# Altium schematic sheet Simplex-Rev2v0.SchDoc(Simplex-Rev2v0)
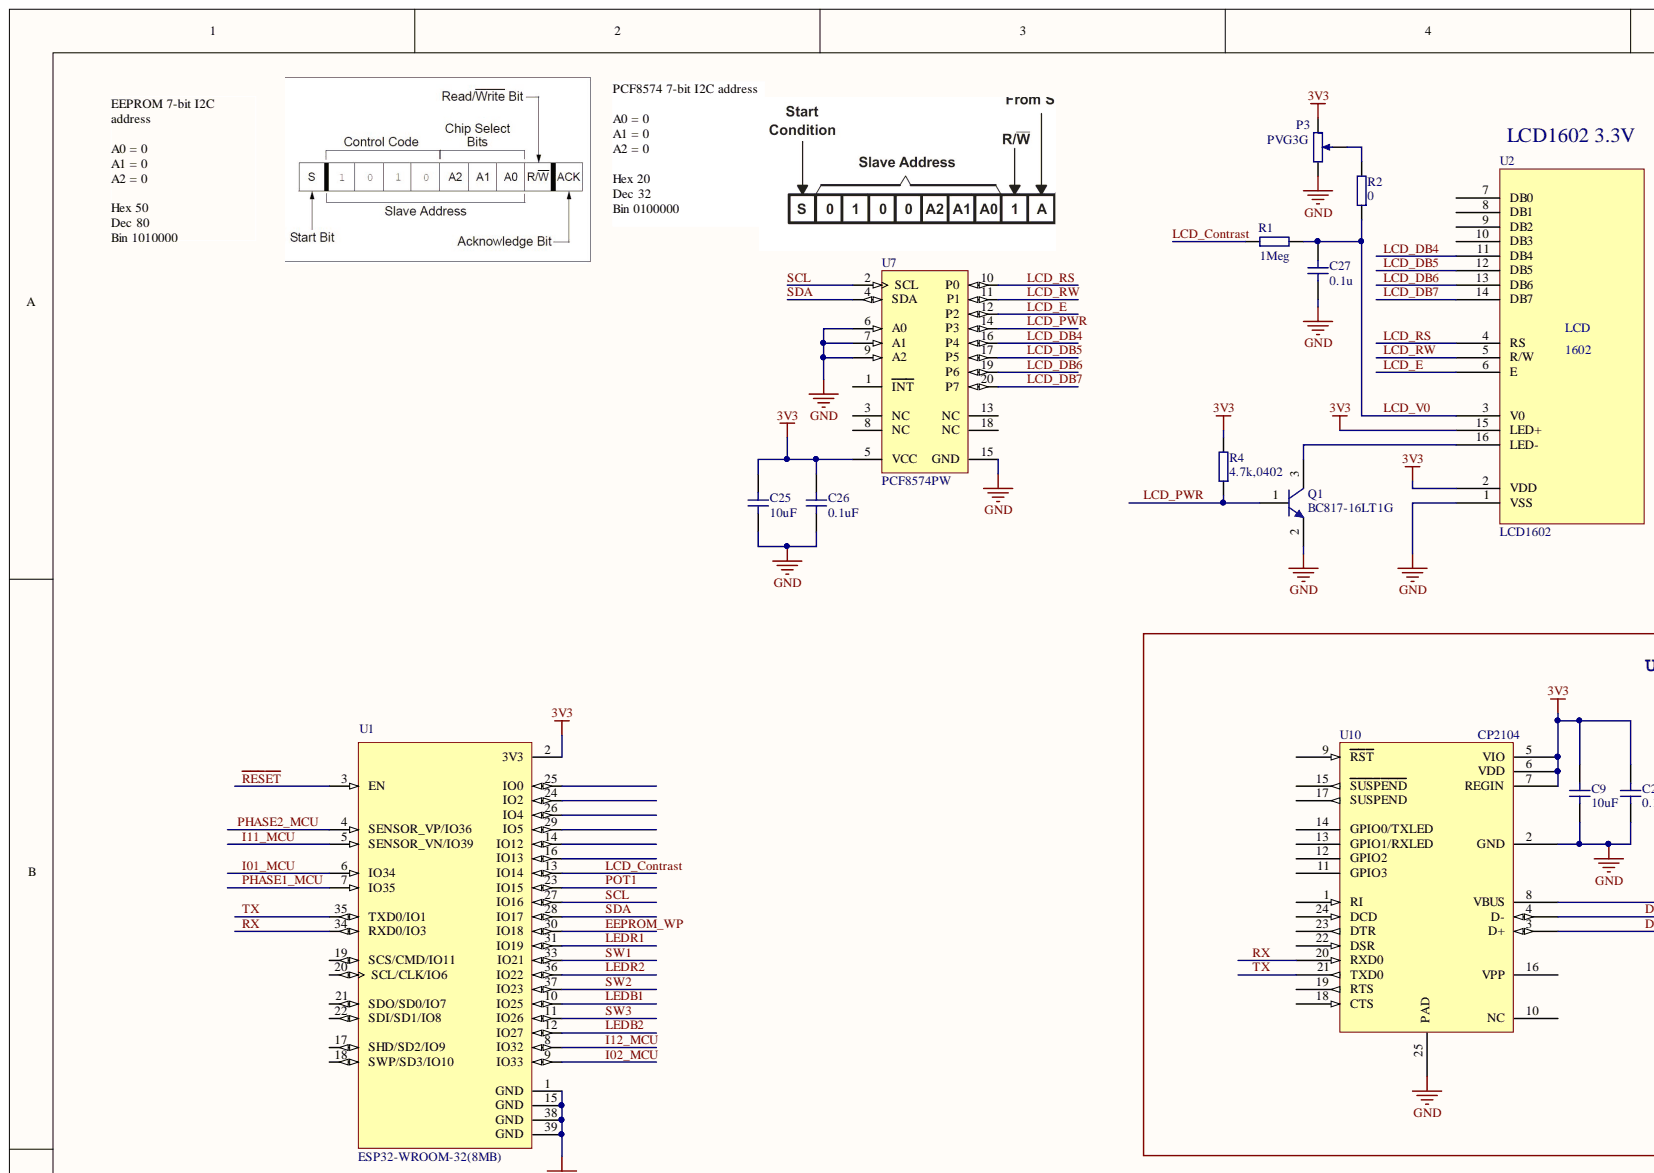
[diagram p0: Simplex-Rev2v0.SchDoc, top left]
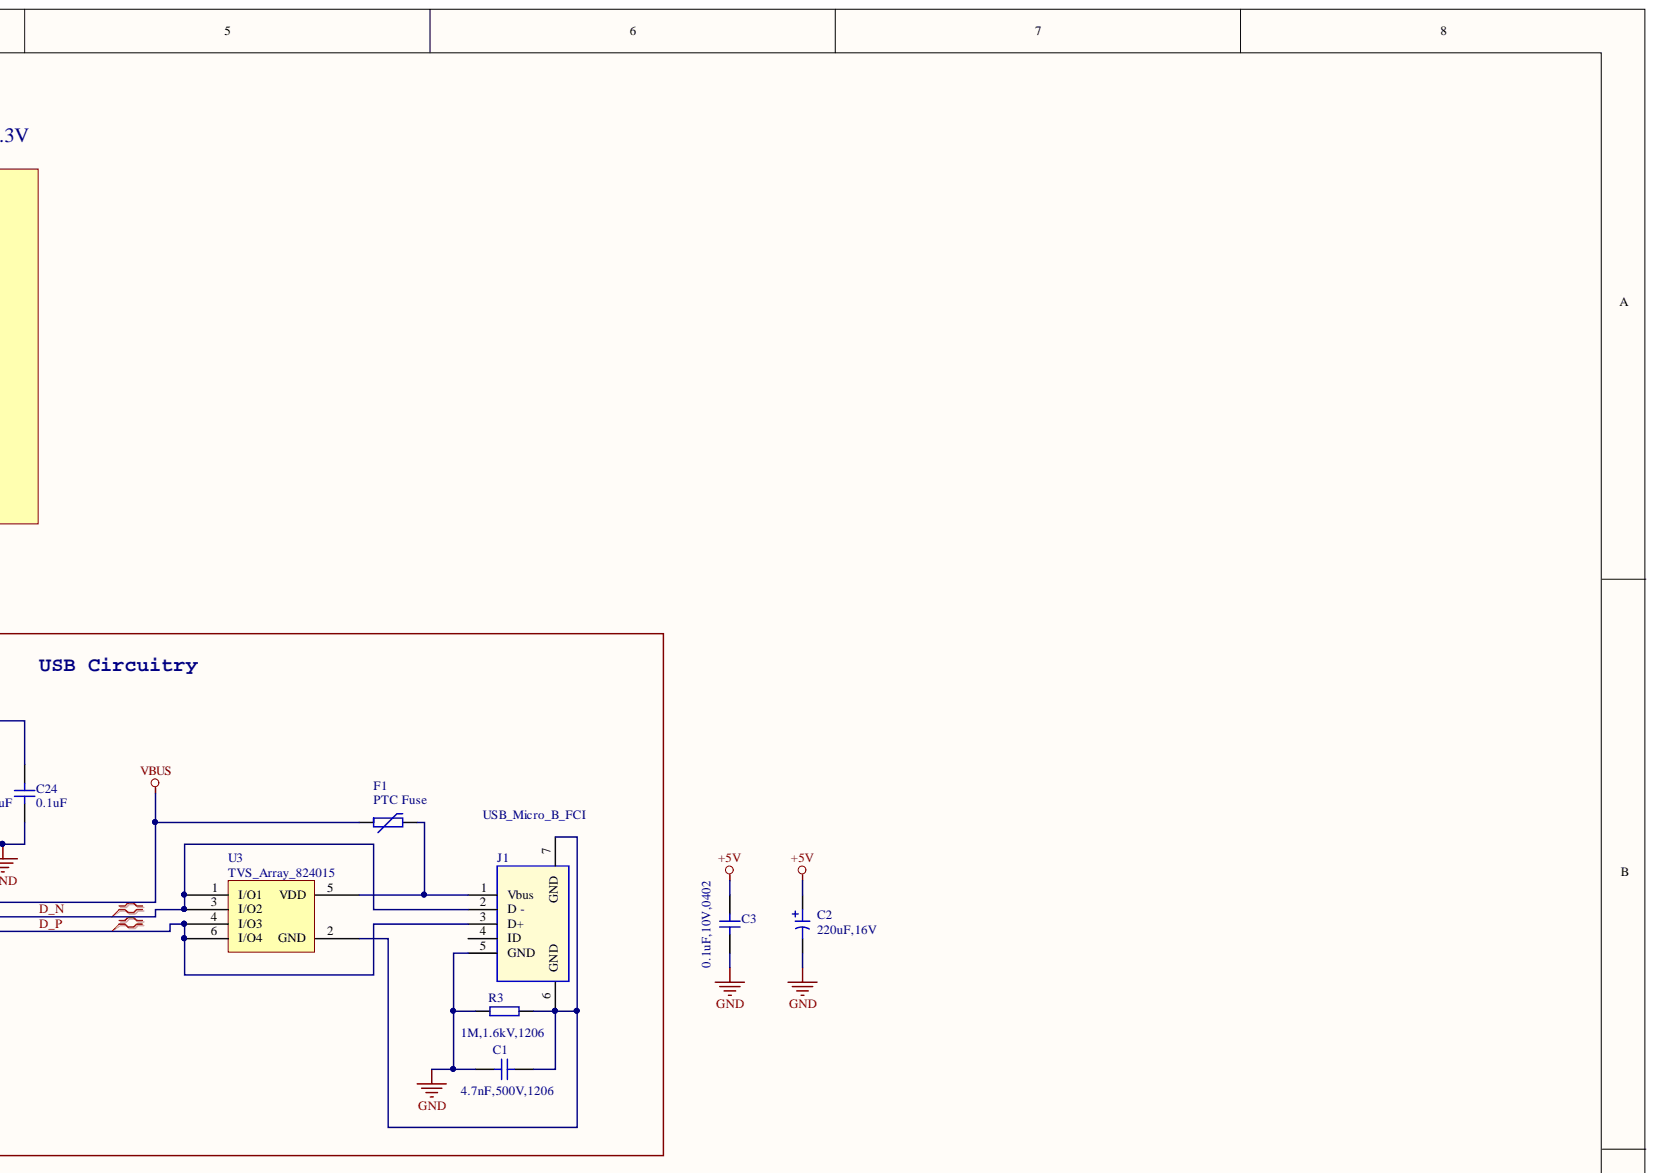
[diagram p1: Simplex-Rev2v0.SchDoc, top right]
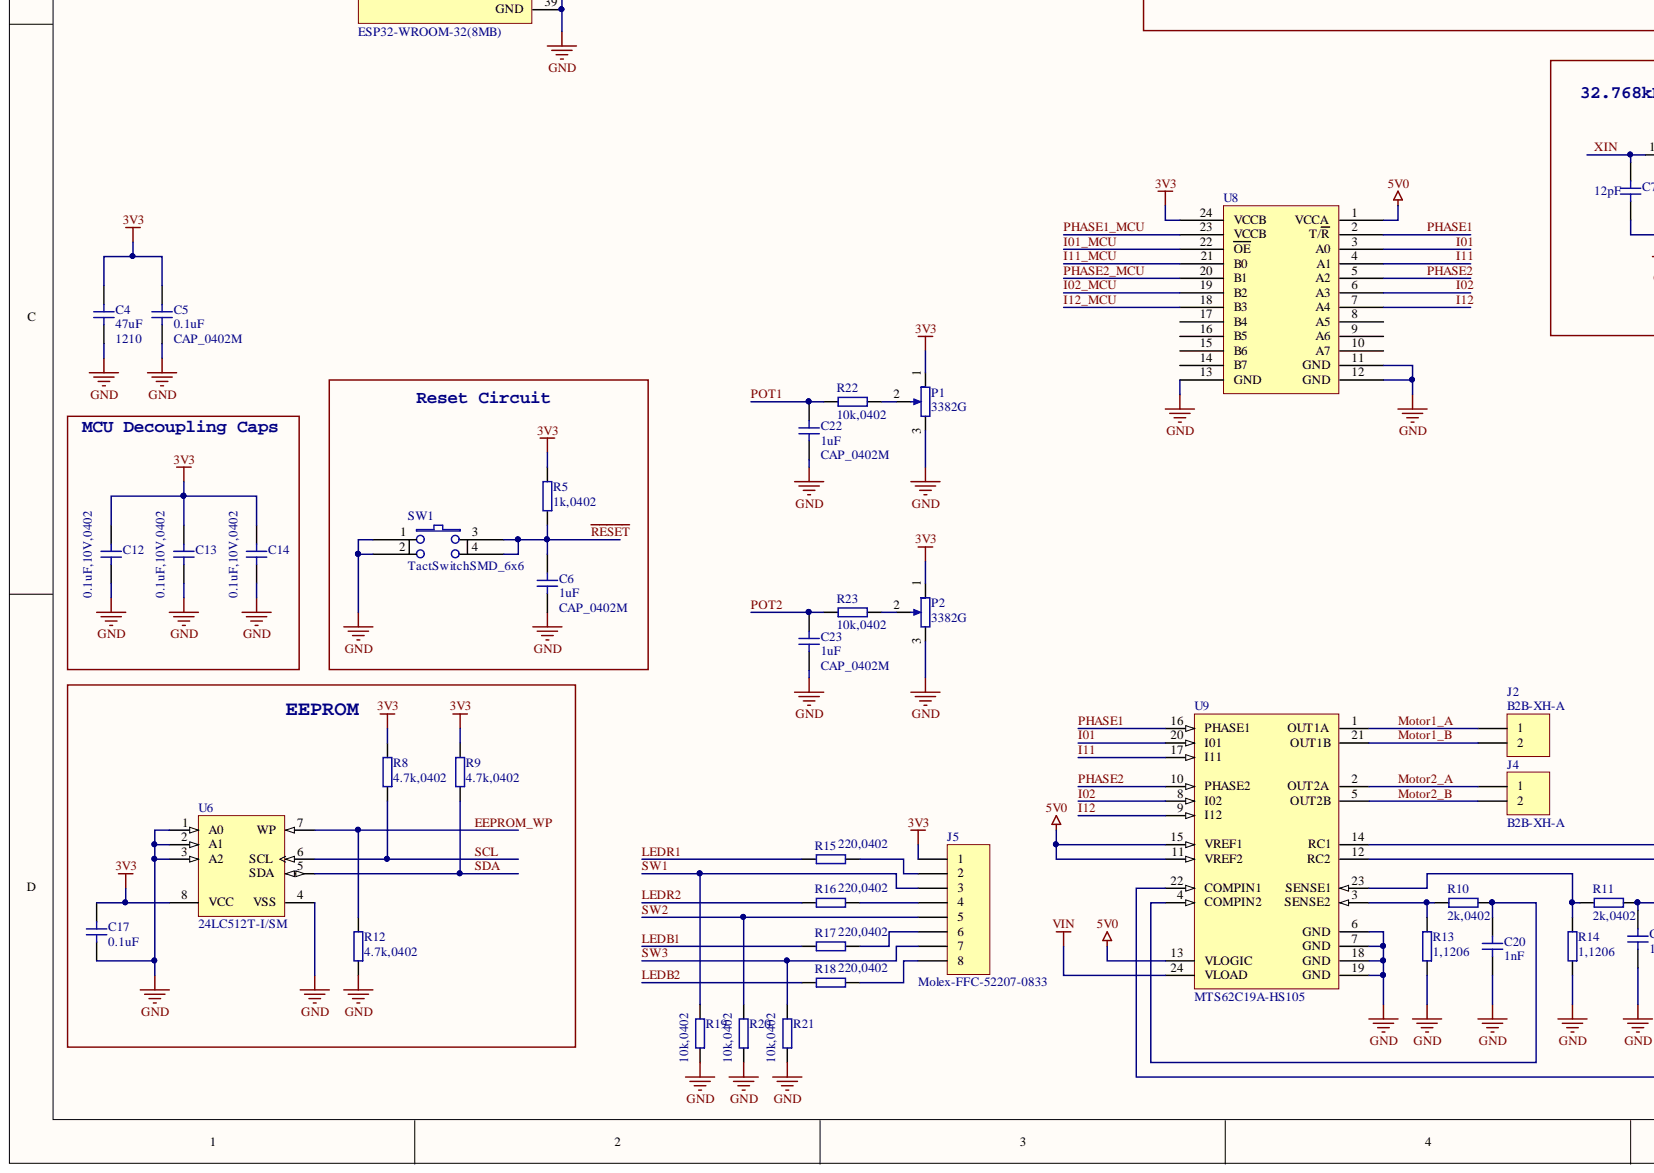
[diagram p2: Simplex-Rev2v0.SchDoc, bottom left]
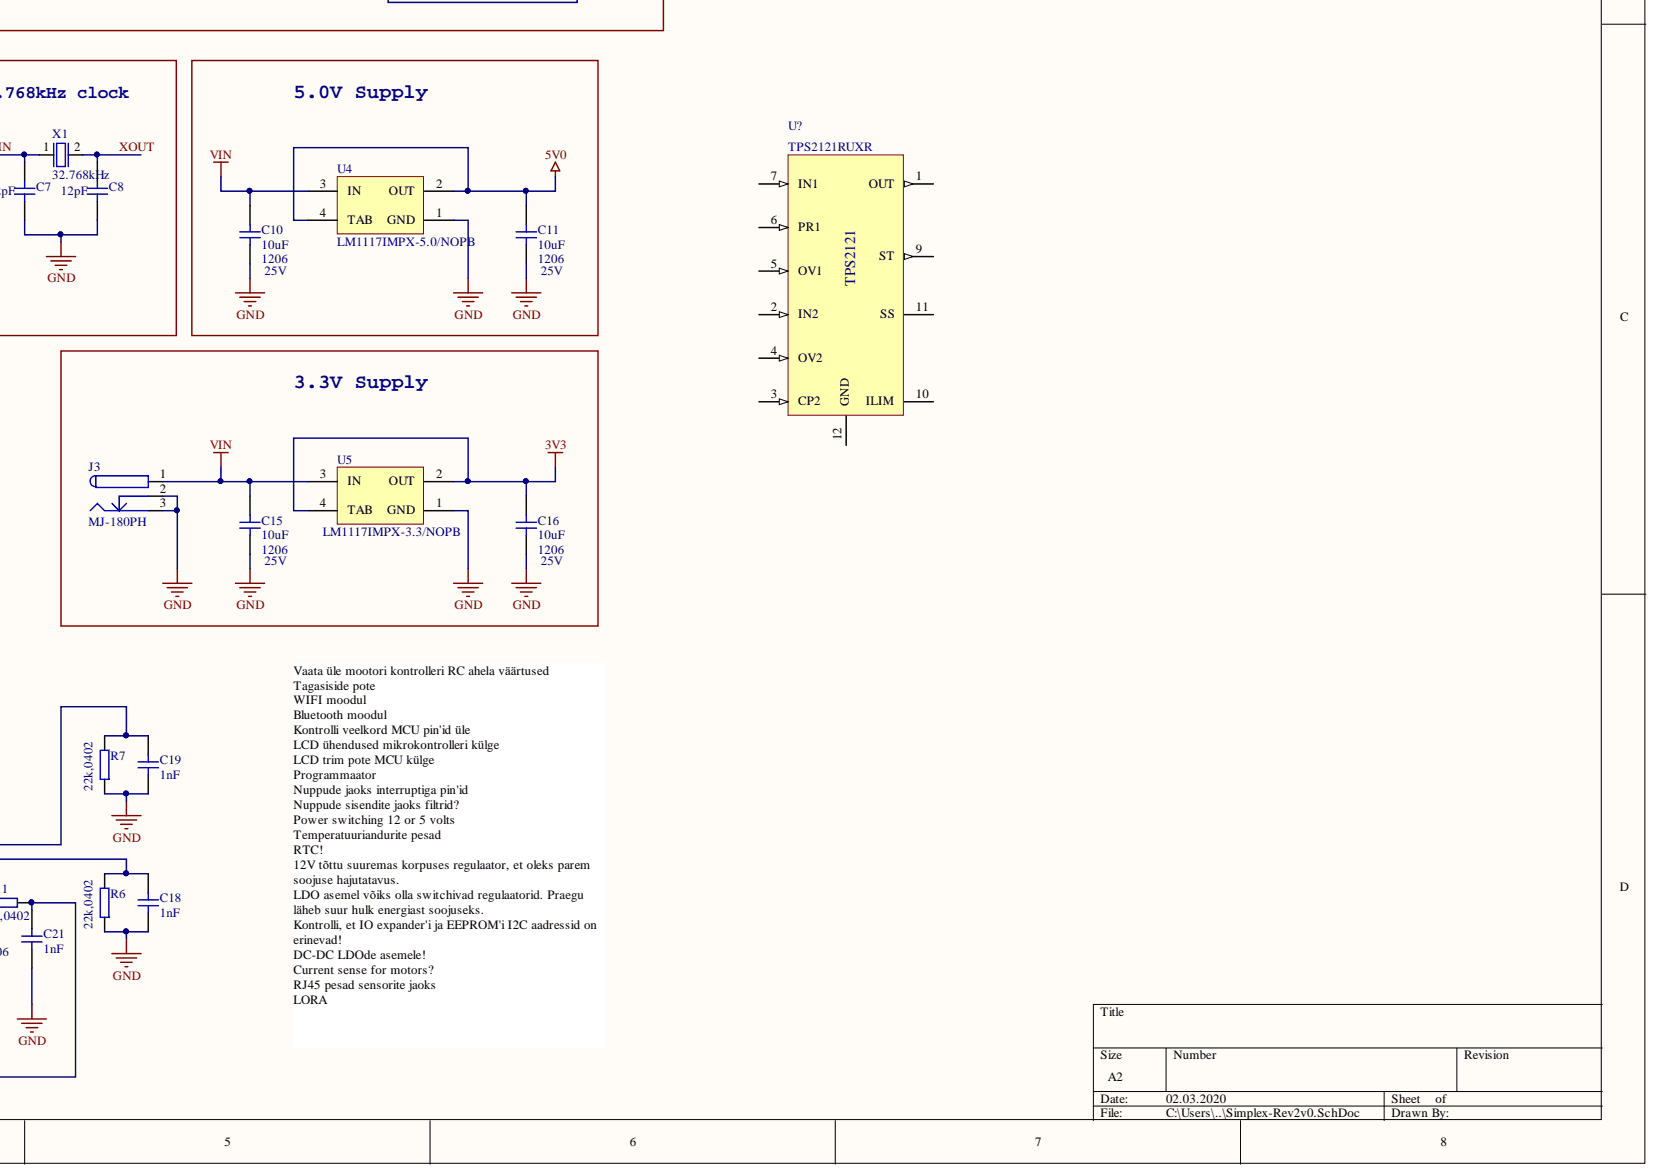
[diagram p3: Simplex-Rev2v0.SchDoc, bottom right]

## Parts
C1  4.7nF,500V,1206  [1206]
C2  220uF,16V  [Electrolytic_6.3x5.4_22u]
C3  0.1uF,10V,0402  [0402]
C4  value 47uF  [1210]  (hidden comment: 47uF,10V,1210)
C5  value 0.1uF  [CAP_0402M]  (hidden comment: 0.1uF,10V,CAP_0402M)
C6  value 1uF  [CAP_0402M]  (hidden comment: 1uF,10V,CAP_0402M)
C7  value 12pF  [0402]  (hidden comment: 12pF,10V,0402)
C8  value 12pF  [0402]  (hidden comment: 12pF,10V,0402)
C9  value 10uF  [CAP_0603_GEN]  (hidden comment: 10uF,10V,CAP_0603_GEN)
C10  value 10uF  Voltage: 25V  [1206]  (hidden comment: 10uF,25V,1206)
C11  value 10uF  Voltage: 25V  [1206]  (hidden comment: 10uF,25V,1206)
C12  0.1uF,10V,0402  [CAP_0402]
C13  0.1uF,10V,0402  [CAP_0402]
C14  0.1uF,10V,0402  [CAP_0402]
C15  value 10uF  Voltage: 25V  [1206]  (hidden comment: 10uF,25V,1206)
C16  value 10uF  Voltage: 25V  [1206]  (hidden comment: 10uF,25V,1206)
C17  value 0.1uF  [CAP_0402M]  (hidden comment: 0.1uF,10V,CAP_0402M)
C18  value 1nF  [CAP_0402M]  (hidden comment: 1nF,10V,CAP_0402M)
C19  value 1nF  [CAP_0402M]  (hidden comment: 1nF,10V,CAP_0402M)
C20  value 1nF  [CAP_0402M]  (hidden comment: 1nF,10V,CAP_0402M)
C21  value 1nF  [CAP_0402M]  (hidden comment: 1nF,10V,CAP_0402M)
C22  value 1uF  [CAP_0402M]  (hidden comment: 1uF,10V,CAP_0402M)
C23  value 1uF  [CAP_0402M]  (hidden comment: 1uF,10V,CAP_0402M)
C24  value 0.1uF  [CAP_0402M]  (hidden comment: 0.1uF,10V,CAP_0402M)
C25  value 10uF  [CAP_0603_GEN]  (hidden comment: 10uF,10V,CAP_0603_GEN)
C26  value 0.1uF  [CAP_0402M]  (hidden comment: 0.1uF,10V,CAP_0402M)
C27  value 0.1u  [CAP_0402M]  (hidden comment: 0.1u,10V,CAP_0402M)
F1  PTC Fuse  [0603_PTC_Fuse]
J1  USB_Micro_B_FCI  [USB_Micro_B_10104110]
J2  B2B-XH-A  [B2B-XH-A]
J3  MJ-180PH  [MJ-180PH]
J4  B2B-XH-A  [B2B-XH-A]
J5  Molex-FFC-52207-0833  [Molex-FFC-52207-0833]
P1  3382G  [3382G]
P2  3382G  [3382G]
P3  PVG3G  [PVG3G]
Q1  BC817-16LT1G  [ONSC-SOT-23-3-318-08_V]
R1  value 1Meg  [RES_0402M]  (hidden comment: 1Meg,RES_0402M)
R2  value 0  [RES_0402M]  (hidden comment: 0,RES_0402M)
R3  1M,1.6kV,1206  [1206]
R4  4.7k,0402  [0402]
R5  1k,0402  [0402]
R6  22k,0402  [0402]
R7  22k,0402  [0402]
R8  4.7k,0402  [0402]
R9  4.7k,0402  [0402]
R10  2k,0402  [0402]
R11  2k,0402  [0402]
R12  4.7k,0402  [0402]
R13  1,1206  [1206]
R14  1,1206  [1206]
R15  220,0402  [0402]
R16  220,0402  [0402]
R17  220,0402  [0402]
R18  220,0402  [0402]
R19  10k,0402  [0402]
R20  10k,0402  [0402]
R21  10k,0402  [0402]
R22  10k,0402  [0402]
R23  10k,0402  [0402]
SW1  TactSwitchSMD_6x6  [TactSwitchSMD_6x6 - 2]
U1  ESP32-WROOM-32(8MB)  [ESP32-WROOM-32(8MB)]
U2  LCD1602  [LCD1602]
U3  TVS_Array_824015  [SOT23-6_N_Thin]
U4  LM1117IMPX-5.0/NOPB  [MP04A_N]
U5  LM1117IMPX-3.3/NOPB  [MP04A_N]
U6  24LC512T-I/SM  [SOIJ-SM8_N]
U7  PCF8574PW  [PW20_N]
U8  74LVX4245MTCX  [TSSOP28_L]  (value not drawn)
U9  MTS62C19A-HS105  [MCHP-SOP-S24_N]
U10  CP2104  [QFN-24]
U?  TPS2121RUXR  [TPS2121RUX]
X1  Frequency: 32.768kHz  [Cryastal_2PIN_SMD]  (hidden comment: Part Number)

## Nets
3V3: C4.2 C5.2 C9.2 C12.1 C13.1 C14.1 C16.2 C17.2 C24.2 C25.2 C26.2 J5.1 P1.1 P2.1 P3.1 R4.2 R5.2 R8.2 R9.2 U1.2(3V3) U2.2(VDD) U2.15(LED+) U5.2(OUT) U5.4(TAB) U6.8(VCC) U7.5(VCC) U8.24(VCCB) U10.5(VIO) U10.6(VDD) U10.7(REGIN)
5V0: C11.2 U4.2(OUT) U4.4(TAB) U8.1(VCCA) U9.11(VREF2) U9.13(VLOGIC) U9.15(VREF1)
+5V: C2.1 C3.2
GND: C1.1 C2.2 C3.1 C4.1 C5.1 C6.1 C7.1 C8.1 C9.1 C10.1 C11.1 C12.2 C13.2 C14.2 C15.1 C16.1 C17.1 C18.1 C19.1 C20.1 C21.1 C22.1 C23.1 C24.1 C25.1 C26.1 C27.1 J1.5(GND) J3.2 J3.3 P1.3 P2.3 P3.3 Q1.2 R3.1 R6.1 R7.1 R12.1 R13.1 R14.1 R19.1 R20.1 R21.1 SW1.1 SW1.2 U1.1(GND) U1.15(GND) U1.38(GND) U1.39(GND) U2.1(VSS) U4.1(GND) U5.1(GND) U6.1(A0) U6.2(A1) U6.3(A2) U6.4(VSS) U7.6(A0) U7.7(A1) U7.9(A2) U7.15(GND) U8.11(GND) U8.12(GND) U8.13(GND) U9.6(GND) U9.7(GND) U9.18(GND) U9.19(GND) U10.2(GND) U10.25(PAD)
VBUS: F1.1 U10.8(VBUS)
VIN: C10.2 C15.2 J3.1 U4.3(IN) U5.3(IN) U9.24(VLOAD)
D_N: J1.2(D_-) U3.1(I/O1) U3.3(I/O2) U10.4(D-)
D_P: J1.3(D+) U3.4(I/O3) U3.6(I/O4) U10.3(D+)
EEPROM_WP: R12.2 U1.30(IO18) U6.7(WP)
I01: U8.3(A0) U9.20(I01)
I01_MCU: U1.6(IO34) U8.22(OE)
I02: U8.6(A3) U9.8(I02)
I02_MCU: U1.9(IO33) U8.19(B2)
I11: U8.4(A1) U9.17(I11)
I11_MCU: U1.5(SENSOR_VN/IO39) U8.21(B0)
I12: U8.7(A4) U9.9(I12)
I12_MCU: U1.8(IO32) U8.18(B3)
LCD_Contrast: R1.1 U1.13(IO14)
LCD_DB4: U2.11(DB4) U7.16(P4)
LCD_DB5: U2.12(DB5) U7.17(P5)
LCD_DB6: U2.13(DB6) U7.19(P6)
LCD_DB7: U2.14(DB7) U7.20(P7)
LCD_E: U2.6(E) U7.12(P2)
LCD_PWR: Q1.1 R4.1 U7.14(P3)
LCD_RS: U2.4(RS) U7.10(P0)
LCD_RW: U2.5(R/W) U7.11(P1)
LCD_V0: C27.2 R1.2 R2.1 U2.3(V0)
LEDB1: R17.1 U1.10(IO25)
LEDB2: R18.1 U1.12(IO27)
LEDR1: R15.1 U1.31(IO19)
LEDR2: R16.1 U1.36(IO22)
Motor1_A: J2.1 U9.1(OUT1A)
Motor1_B: J2.2 U9.21(OUT1B)
Motor2_A: J4.1 U9.2(OUT2A)
Motor2_B: J4.2 U9.5(OUT2B)
PHASE1: U8.2(T/R) U9.16(PHASE1)
PHASE1_MCU: U1.7(IO35) U8.23(VCCB)
PHASE2: U8.5(A2) U9.10(PHASE2)
PHASE2_MCU: U1.4(SENSOR_VP/IO36) U8.20(B1)
POT1: C22.2 R22.1 U1.23(IO15)
POT2: C23.2 R23.1
~{RESET}: C6.2 R5.1 SW1.3 SW1.4 U1.3(EN)
RX: U1.34(RXD0/IO3) U10.20(RXD0)
SCL: R8.1 U1.27(IO16) U6.6(SCL) U7.2(SCL)
SDA: R9.1 U1.28(IO17) U6.5(SDA) U7.4(SDA)
SW1: J5.3 R19.2 U1.33(IO21)
SW2: J5.5 R20.2 U1.37(IO23)
SW3: J5.7 R21.2 U1.11(IO26)
TX: U1.35(TXD0/IO1) U10.21(TXD0)
XIN: C7.2 X1.1
XOUT: C8.2 X1.2
NetC1_2: C1.2 J1.6(GND) J1.7(GND) R3.2 U3.2(GND)
NetC18_2: C18.2 R6.2 U9.12(RC2)
NetC19_2: C19.2 R7.2 U9.14(RC1)
NetC20_2: C20.2 R10.2 U9.4(COMPIN2)
NetC21_2: C21.2 R11.2 U9.22(COMPIN1)
NetF1_2: F1.2 J1.1(Vbus) U3.5(VDD)
NetJ5_2: J5.2 R15.2
NetJ5_4: J5.4 R16.2
NetJ5_6: J5.6 R17.2
NetJ5_8: J5.8 R18.2
NetP1_2: P1.2 R22.2
NetP2_2: P2.2 R23.2
NetP3_2: P3.2 R2.2
NetQ1_3: Q1.3 U2.16(LED-)
NetR10_1: R10.1 R13.2 U9.3(SENSE2)
NetR11_1: R11.1 R14.2 U9.23(SENSE1)

Not connected: J1 4(ID); U1 14(IO12) 16(IO13) 17(SHD/SD2/IO9) 18(SWP/SD3/IO10) 19(SCS/CMD/IO11) 20(SCL/CLK/IO6) 21(SDO/SD0/IO7) 22(SDI/SD1/IO8) 24(IO2) 25(IO0) 26(IO4) 29(IO5); U2 7(DB0) 8(DB1) 9(DB2) 10(DB3); U7 1(INT) 3(NC) 8(NC) 13(NC) 18(NC); U8 8(A5) 9(A6) 10(A7) 14(B7) 15(B6) 16(B5) 17(B4); U10 1(RI) 9(RST) 10(NC) 11(GPIO3) 12(GPIO2) 13(GPIO1/RXLED) 14(GPIO0/TXLED) 15(SUSPEND) 16(VPP) 17(SUSPEND) 18(CTS) 19(RTS) 22(DSR) 23(DTR) 24(DCD); U? 1(OUT) 2(IN2) 3(CP2) 4(OV2) 5(OV1) 6(PR1) 7(IN1) 9(ST) 10(ILIM) 11(SS) 12(GND)

## Library data of the parts
C1 = CAPACITOR: ManufacturerPartCode: MC1206B472K501CT; Manufacturer: MULTICOMP; Voltage: 500V; Value: 4.7nF; FarnellURL: http://ee.farnell.com/multicomp/mc1206b472k501ct/cap-mlcc-x7r-4-7nf-500v-1206/dp/2320919; FarnellCode: 2320919; Description: Capacitor; DatasheetURL: http://www.farnell.com/datasheets/1825493.pdf; Component Kind: Standard; Library Name: WirelessVibrationSensor.IntLib
C2 = Capacitor_POL: Polarized Capacitor; VoltageRating: 16V; Value: 220uF; Component Kind: Standard; Library Name: JPOL.IntLib
C3-C11 C15-C27 = CAPACITOR: Description: Capacitor; Component Kind: Standard; Library Name: WirelessVibrationSensor.IntLib
  C3: Voltage: 10V; Value: 0.1uF
  C4-C9 C17-C27: Voltage: 10V
C12-C14 = CAPACITOR: value: 100n; Description: Capacitor; Component Kind: Standard; Library Name: WirelessVibrationSensor.IntLib
F1 = PTC Fuse: FUSE, RESETTABLE PTC; Library Name: CompSensor.IntLib; Component Kind: Standard
J1 = USB_Micro_B_FCI: MICRO USB, 2.0 TYPE B, RECEPTACLE, SMD; ManufacturerPartCode: 10104110-0001LF; Manufacturer: FCI; Library Name: CompSensor.IntLib; FarnellURL: http://ee.farnell.com/fci/10104110-0001lf/micro-usb-2-0-type-b-receptacle/dp/2293753; FarnellCode: 2293753; DatasheetURL: http://www.farnell.com/datasheets/1469072.pdf; Component Kind: Standard
J2 J4 = B2B-XH-A: 1 x 2 Header, 2.5mm; Library Name: CompSensor.IntLib; FarnellCode: 1516276; Component Kind: Standard
J3 = MJ-180PH: SOCKET, PCB, DC POWER, 12VDC, 4A; ManufacturerPartCode: 1737251; Manufacturer: MULTICOMP; Library Name: CompSensor.IntLib; FarnellURL: http://ee.farnell.com/multicomp/mj-180ph/socket-pcb-dc-power-12vdc-4a/dp/1737251; FarnellCode: 1737251; Component Kind: Standard
J5 = FFC-8-1mm: Header, 8-Pin, 52207-0833, 1mm pitch; Library Name: CompSensor.IntLib; LatestRevisionNote: Re-released for DXP Platform.; LatestRevisionDate: 17-Jul-2002; Farnell: 2361290; Component Kind: Standard; Publisher: Altium Limited
P1 P2 = Pot-3382G: 3006P-1-203LF -  Trimmer Potentiometer, 20 kohm, 15 Turns, Through Hole, Trimpot 3006 Series, 750 mW, ± 10%; Manufacturer: BOURNS; Value: 10k; Supplier Part Number 2: 652-3382G-1-103G; Supplier Part Number 1: 1717387; Supplier 2: Mouser; Supplier 1: Farnell; ManufacturerPartCode: 3006P-1-203LF; Library Name: CompSensor.IntLib; FarnellURL: http://ee.farnell.com/bourns/3006p-1-103lf/pot-trimmer-10k-15-turn-3-pin/dp/9352295?ICID=TREML010-010; FarnellCode: 1717387; DatasheetURL: https://www.bourns.com/docs/Product-Datasheets/3006.pdf; ComponentLink1URL: https://www.bourns.com/docs/Product-Datasheets/3006.pdf; ComponentLink1Description: Datasheet; Component Kind: Standard
P3 = PVG3G: Trimmer Potentiometer,1 Turn, SMD; Manufacturer: Bourns; ManufacturerPartCode: PVG3G; Library Name: CompSensor.IntLib; FarnellURL: http://ee.farnell.com/bourns/pvg3g104c01r00/trimmer-pot-100k-1turn-smd/dp/2709500; Component Kind: Standard
Q1 = BC817-16LT1G: General Purpose Transistor, NPN Silicon, 3-Pin SOT-23, Pb-Free, Tape and Reel; Manufacturer: On Semiconductor; IC Continuous (A): 0.5; fT Min (MHz): 100; Supplier Part Number 7: 863-BC817-16LT1G; Supplier Part Number 6: 1459035; Supplier Part Number 5: 1459035RL; Supplier Part Number 4: 2317901; Supplier Part Number 3: BC817-16LT1GOSDKR-ND; Supplier Part Number 2: BC817-16LT1GOSCT-ND; Supplier Part Number 1: BC817-16LT1GOSTR-ND; Supplier 7: Mouser; Supplier 6: Farnell; Supplier 5: Farnell; Supplier 4: Farnell; Supplier 3: Digi-Key; Supplier 2: Digi-Key; Supplier 1: Digi-Key; RoHS: TRUE; Polarity: NPN; PartNumber: BC817-16LT1G; Packing: Tape and Reel; PackageVersion: Rev. AP, 11/2009; PackageReference: SOT-23-3-318-08; PackageDescription: 3-Pin Small Outline Transistor, Body 2.92 x 1.3 mm, Pitch 0.95 mm; Mounting Technology: Surface Mount; Library Name: ON Semi General Purpose Transistors.IntLib; DatasheetVersion: Rev. 12; ComponentLink3URL: http://www.onsemi.cn/pub_link/Collateral/318-08.PDF; ComponentLink3Description: Package Specification; ComponentLink2URL: http://www.onsemi.com/pub_link/Collateral/BC817-16LT1-D.PDF; ComponentLink2Description: Datasheet; ComponentLink1URL: http://www.onsemi.com/; ComponentLink1Description: Manufacturer URL; Component Kind: Standard
R1 R2 R4-R12 R19-R23 = RESISTOR: Description: resistor; Component Kind: Standard; Library Name: WirelessVibrationSensor.IntLib
  R4 R8 R9 R12: Value: 4.7k
  R5: Value: 1k
  R6 R7: Value: 22k
  R10 R11: Value: 2k
  R19-R23: Value: 10k
R3 = RESISTOR: ManufacturerPartCode: CHV1206-JW-105ELF; Manufacturer: BOURNS; Voltage: 1.6kV; Value: 1M; FarnellURL: http://ee.farnell.com/bourns/chv1206-jw-105elf/resistor-thick-film-1mohm-5-1206/dp/2470932; FarnellCode: 2470932; Description: resistor; DatasheetURL: http://www.farnell.com/datasheets/1889918.pdf; Component Kind: Standard; Library Name: WirelessVibrationSensor.IntLib
R13 R14 = RESISTOR: Value: 1; Description: resistor; Component Kind: Standard; Library Name: WirelessVibrationSensor.IntLib
R15-R18 = RESISTOR: Value: 220; Description: resistor; Component Kind: Standard; Library Name: WirelessVibrationSensor.IntLib
U1 = ESP32-WROOM-32(8MB): WIFI MODULE 64 MBITS SPI FLASH; MP: ESP32-WROOM-32 _8MB_; MF: Espressif Systems; SnapEDA_Link: https://www.snapeda.com/parts/ESP32-WROOM-32%20%288MB%29/Espressif%20Systems/view-part/?ref=snap; Price: None; Package: Module Espressif Systems; Library Name: CompSensor.IntLib; Component Kind: Standard; Availability: Unavailable
U3 = TVS_Array_824015: TVS Diode Array WE-TVS; ManufacturerPartCode: 824015; Manufacturer: Würth Elektronik; Library Name: CompSensor.IntLib; FarnellURL: http://ee.farnell.com/wurth-elektronik/824015/diode-array-tvs/dp/1748615; FarnellCode: 1748615; DatasheetURL: http://www.farnell.com/datasheets/618798.pdf; Component Kind: Standard
U4 = LM1117IMPX-5.0/NOPB: 800mA Low-Dropout Linear Regulator, 4-pin SOT-223, Pb-Free; Manufacturer: National Semiconductor; PowerWise: N; PackageTopMarking: XYTT*N06B; High-Reliability: Y; CompType: LDO IC; RoHS: Y; PinCount: 4; PartNumber: LM1117IMPX-5.0/NOPB; PackageVersion: revB, Apr-2003; PackageReference: MP04A; PackageDescription: Molded SOT-223, 6.50 x 3.56 x 1.6mm Body, 4 Lead, 2.3mm Pitch; Library Name: National Semiconductor LDO.IntLib; DatasheetVersion: Apr-2006; ComponentLink4URL: http://www.national.com/packaging/folders/mp04a.html; ComponentLink4Description: MP04A Package Page; ComponentLink3URL: http://www.national.com/pf/LM/LM1117.html; ComponentLink3Description: LM1117 Web Page; ComponentLink2URL: http://www.national.com/ds/LM/LM1117.pdf; ComponentLink2Description: LM1117 Datasheet; ComponentLink1URL: http://www.national.com/; ComponentLink1Description: Manufacturer URL; Component Kind: Standard; Code_JEDEC: TO-261-AA; Code_IPC: SOT223-4
U5 = LM1117IMPX-3.3/NOPB: 800mA Low-Dropout Linear Regulator, 4-pin SOT-223, Pb-Free; Manufacturer: National Semiconductor; PowerWise: N; PackageTopMarking: XYTT*N05B; CompType: LDO IC; Supplier Part Number 1: LM1117IMPX-3.3-ND; Supplier 1: Digi-Key; RoHS: Y; Published: 1-Mar-2010; PinCount: 4; PartNumber: LM1117IMPX-3.3/NOPB; PackageVersion: revB, Apr-2003; PackageReference: MP04A; PackageDescription: Molded SOT-223, 6.50 x 3.56 x 1.6mm Body, 4 Lead, 2.3mm Pitch; Library Name: NSC LDO.IntLib; DatasheetVersion: Apr-2006; ComponentLink3URL: http://www.national.com/packaging/folders/mp04a.html; ComponentLink3Description: MP04A Package Page; ComponentLink2URL: http://www.national.com/pf/LM/LM1117.html; ComponentLink2Description: LM1117 Web Page; ComponentLink1URL: http://www.national.com/ds/LM/LM1117.pdf; ComponentLink1Description: LM1117 Datasheet; Component Kind: Standard; Code_JEDEC: TO-261-AA; Code_IPC: SOT223-4; Publisher: Altium Limited
U6 = 24LC512T-I/SM: 512Kbit, 400kHz, 2.5V, I2C Serial EEPROM, 8-Pin SOIC 208mil, Industrial Temperature, Tape and Reel; Supplier Part Number 1: 24LC512T-I/SMTR-ND; Supplier 1: Digi-Key; Published: 29-Jan-2009; PackageVersion: revBB, Aug-2009; PackageReference: SOIJ-SM8; PackageDescription: 8-Lead Plastic Small Outline (SM) - Medium, 5.28 mm Body [SOIJ]; Library Name: Microchip Memory I2C Serial EEPROM.IntLib; DatasheetVersion: revJ, Jul-2008; ComponentLink3URL: http://www.microchip.com/; ComponentLink3Description: Microchip.com Home; ComponentLink2URL: http://www.microchip.com/stellent/groups/techpub_sg/documents/packagingspec/en012702.pdf; ComponentLink2Description: Packaging Specification; ComponentLink1URL: http://ww1.microchip.com/downloads/en/DeviceDoc/21754J.pdf; ComponentLink1Description: Datasheet; Component Kind: Standard; Publisher: Altium Limited
U7 = PCF8574PW: Remote 8-Bit I/O Expander for I2C-Bus, 2.5 to 6 V, -40 to 85 degC, 20-pin TSSOP (PW), Green (RoHS & no Sb/Br); Manufacturer: Texas Instruments; Voltage Nodes(V): 5, 3.3; Vcc range(V): 2.5 to 6.0; VCC(Min)(V): 2.5; VCC(Max)(V): 6; SubFamily: I2C IO Expander; Push-Pull I/O Type: Yes; Max Frequency(kHz): 100; I2C Address: 0100 xxx; fSCLK(Max)(MHz): 0.1; # of I/Os: 8; Supplier Part Number 3: 595-PCF8574PW; Supplier Part Number 2: 02H5307; Supplier Part Number 1: 296-26295-5-ND; Supplier 3: Mouser; Supplier 2: Newark; Supplier 1: Digi-Key; Reset Input: No; PartNumber: PCF8574PW; PackageVersion: revG, Feb-2011; PackageReference: PW20; PackageDescription: 20-Pin Plastic Thin Small Outline Package 6.5 x 4.4 mm Body ,0.65 mm Pitch; Mounting Technology: Surface Mount; Library Name: Texas Instruments Interface I2C.IntLib; Interrupt Output: Yes; Eco-Plan: Green (RoHS & no Sb/Br); DatasheetVersion: SCPS068G; ComponentLink1URL: http://www.ti.com/general/docs/lit/getliterature.tsp?genericPartNumber=PCF8574&fileType=pdf; ComponentLink1Description: Datasheet; Component Kind: Standard; Code_JEDEC: MO-153
U8 = Level-Shifter-74LVX4245: Translating Transceiver; Manufacturer: ON SEMICONDUCTOR; Supplier Part Number 2: 512-74LVX4245MTCX; Supplier Part Number 1: 1467357; Supplier 2: Mouser; Supplier 1: Farnell; ManufacturerPartCode: 74LVX4245MTCX; Library Name: CompSensor.IntLib; ComponentLink1URL: http://www.farnell.com/datasheets/2298327.pdf?_ga=2.243817600.405597854.1544005929-113913289.1514658873; ComponentLink1Description: Datasheet; Component Kind: Standard
U9 = MTS62C19A-HS105: Dual Full-Bridge Motor Driver, MOSFET Output, 10 to 40 V, 24-pin SOP (S24), Tube; Manufacturer: Microchip; Supplier Part Number 6: 579-MTS62C19A-HS105; Supplier Part Number 5: 13T4440; Supplier Part Number 4: 2009303; Supplier Part Number 3: MTS62C19A-HS105DKR-ND; Supplier Part Number 2: MTS62C19A-HS105TR-ND; Supplier Part Number 1: MTS62C19A-HS105-ND; Supplier 6: Mouser; Supplier 5: Newark; Supplier 4: Farnell; Supplier 3: Digi-Key; Supplier 2: Digi-Key; Supplier 1: Digi-Key; PartNumber: MTS62C19A-HS105; PackageVersion: Rev. B; PackageReference: SOP-S24; PackageDescription: 24-Lead Small Outline Package, 15.7 x 7.5 mm Body, 0.5 mm Pitch; Mounting Technology: Surface Mount; Library Name: Microchip Motor Driver.IntLib; DatasheetVersion: Rev. B; ComponentLink4URL: http://www.microchip.com/MTS62C19A; ComponentLink4Description: Product URL; ComponentLink3URL: http://ww1.microchip.com/downloads/en/DeviceDoc/22259B.pdf; ComponentLink3Description: Package Specification; ComponentLink2URL: http://ww1.microchip.com/downloads/en/DeviceDoc/22260B.pdf; ComponentLink2Description: Datasheet; ComponentLink1URL: http://www.microchip.com; ComponentLink1Description: Manufacturer URL; Component Kind: Standard; Code_JEDEC: MO-119
U10 = CP2104: USB-to-UART Interface, USB 2.0, 3 V, 5.25 V, QFN, 24; Manufacturer: Silicon Labs; Supplier Part Number 3: 336-4146-1-ND; Supplier Part Number 2: 634-CP2104-F03-GM; Supplier Part Number 1: 2930580; Supplier 3: Digi-Key; Supplier 2: Mouser; Supplier 1: Farnell; PartNumber: CP2104; PackageDescription: QFN-24; ManufacturerPartNumber: CP2104; ManufacturerPartCode: CP2104; Library Name: CompSensor.IntLib; DatasheetURL: https://www.silabs.com/documents/public/data-sheets/cp2104.pdf; ComponentLink1URL: https://www.silabs.com/documents/public/data-sheets/cp2104.pdf; ComponentLink1Description: Datasheet; Component Kind: Standard
U? = TPS2121RUXR: PWR MGMT BATTERY MGMT; MF: Texas Instruments; SnapEDA_Link: https://www.snapeda.com/parts/TPS2121RUXR/Texas%20Instruments/view-part/?ref=snap; Price: None; Package: VFQFN-12 Texas Instruments; MP: TPS2121RUXR; Library Name: CompSensor.IntLib; Component Kind: Standard; Availability: Unavailable
X1 = XTAL_32768Hz: XTAL, 2Pin, 32.768kHz, 7pF 20ppm; Part Name: XTAL 2Pin 32.768kHz 3215 7pF; Part_Type: Crystal/Oscillator; ManufacturerPartCode: LFXTAL009709; Manufacturer: IQD FREQUENCY PRODUCTS; MFG Package: 2-SMD; Component Mounting Type: SMT; Body Size: 3.2mm x 1.5mm; Supplier Part Number 1: 2449501; Supplier 1: Farnell; Revision: 0A; Pins: 2; Manufacturer P/N: LFXTAL009709; Library Name: CompSensor.IntLib; Height: 0.9mm; Frequency Tolerance: 20ppm; FarnellURL: http://ee.farnell.com/iqd-frequency-products/lfxtal009709/crystal-32-768khz-7pf-smd/dp/2449501; Component Kind: Standard; CL: 7pF
SW1 = TactSwitchSMD_6x6: Library Name: CompSensor.IntLib; Component Kind: Standard
U2 = LCD1602: Library Name: CompSensor.IntLib; Component Kind: Standard
